# Altium schematic sheet Power.SchDoc
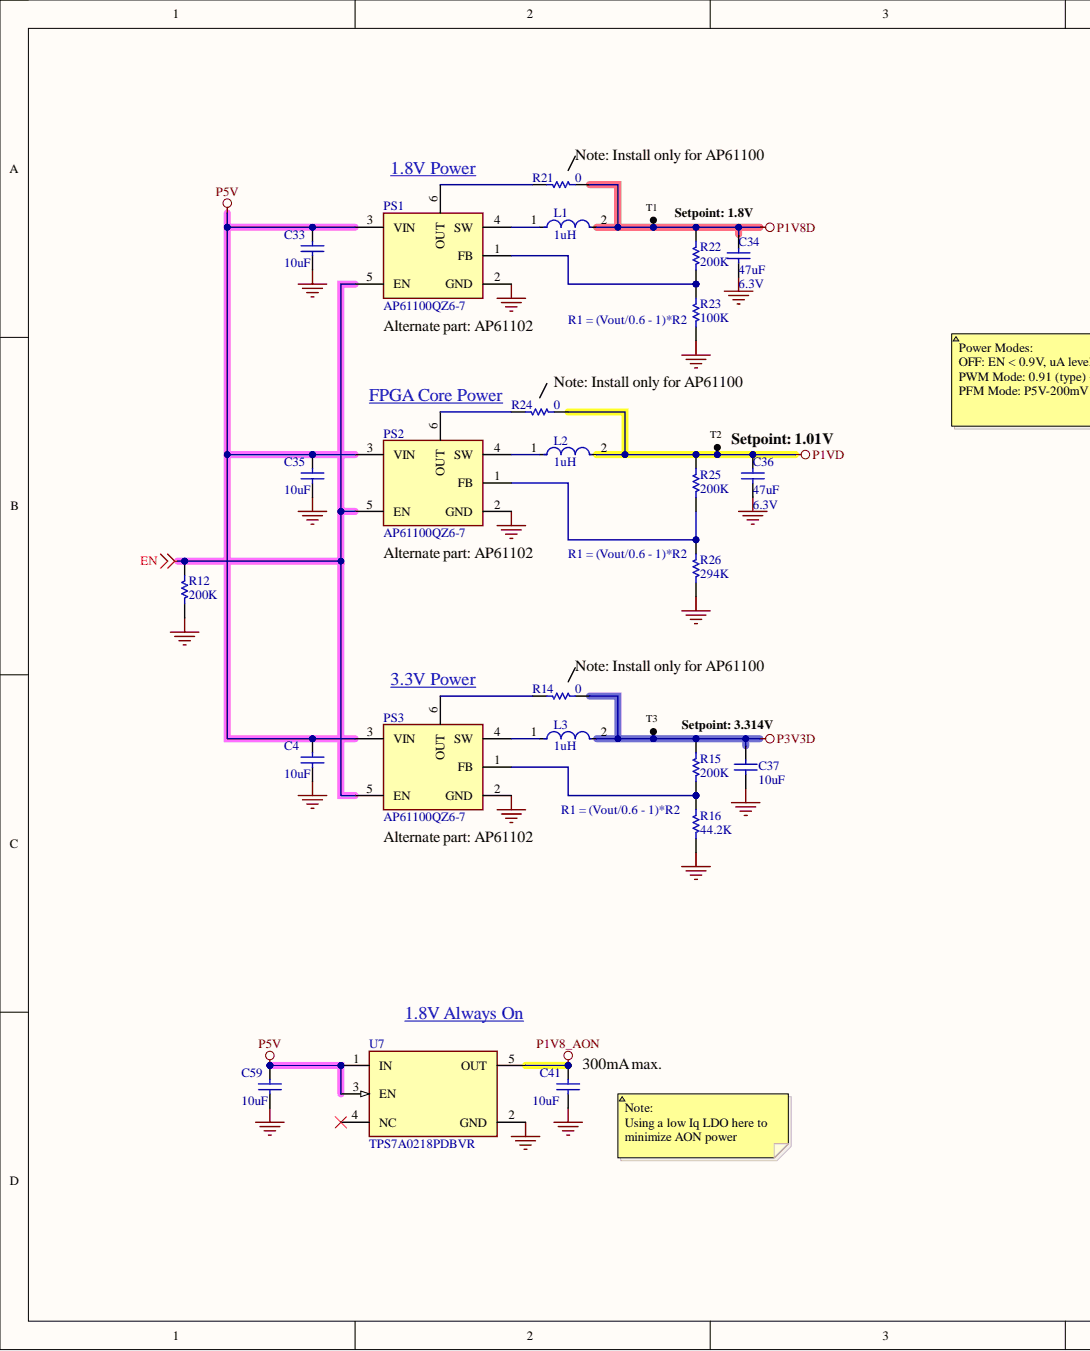
[diagram p0: Power.SchDoc, left]
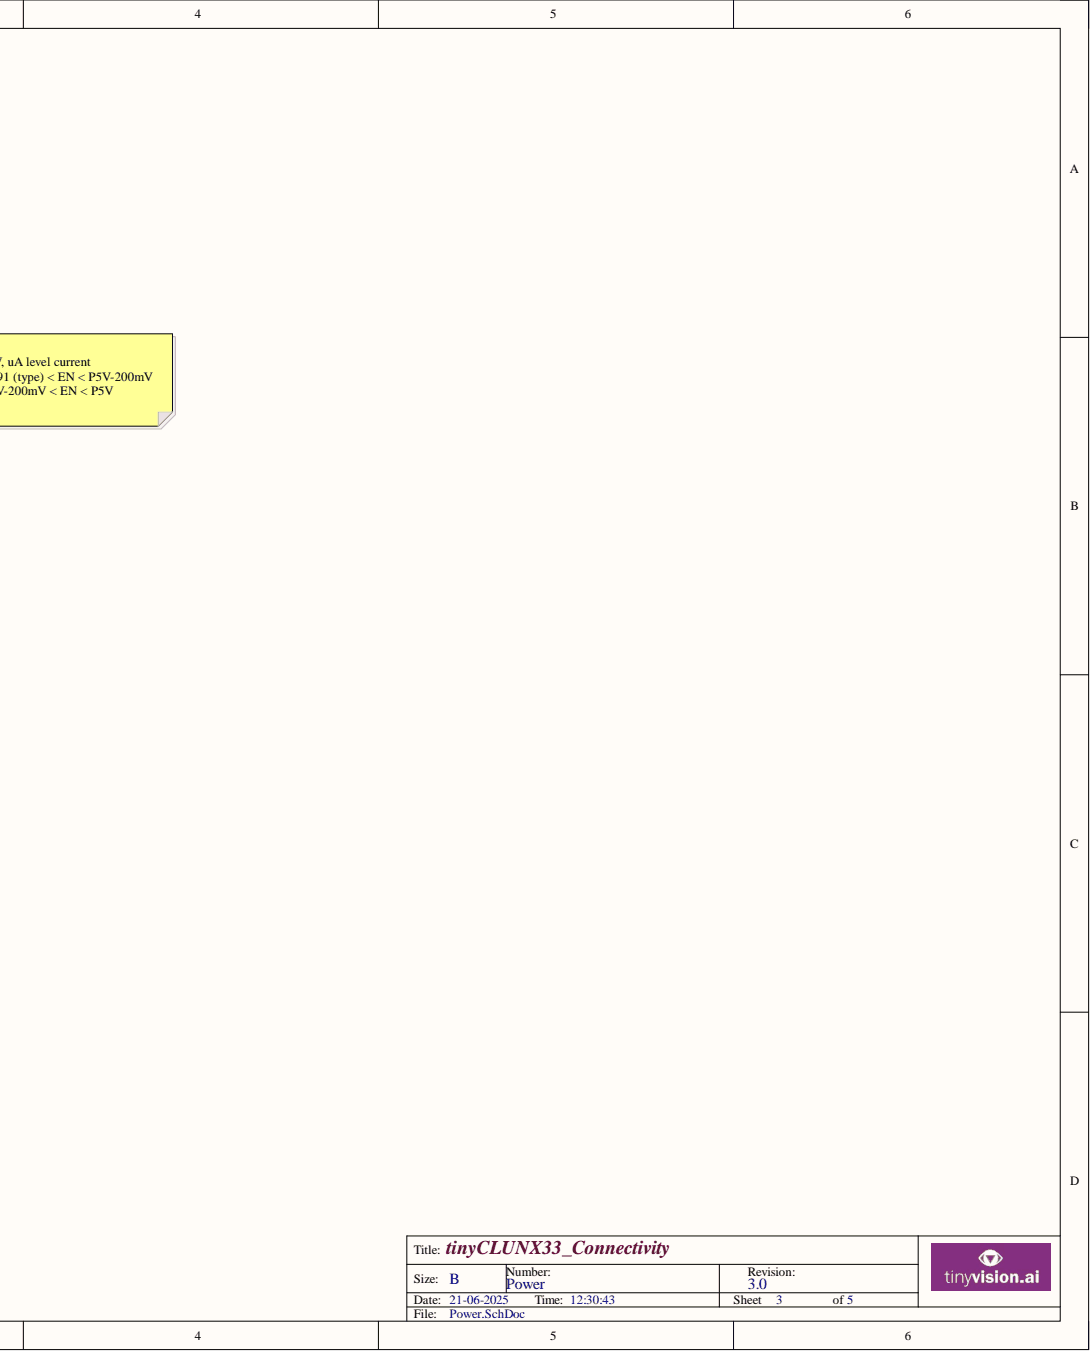
[diagram p1: Power.SchDoc, right]

## Parts
C4  10uF  [CAPC1005X55USR]
C33  10uF  [CAPC1005X55USR]
C34  47uF  Voltage: 6.3V  [CAP_0603]
C35  10uF  [CAPC1005X55USR]
C36  47uF  Voltage: 6.3V  [CAP_0603]
C37  10uF  [CAPC1005X55USR]
C41  10uF  [CAPC1005X55USR]
C59  10uF  [CAPC1005X55USR]
L1  1uH  [INDC2016X100N]
L2  1uH  [INDC2016X100N]
L3  1uH  [INDC2016X100N]
PS1  AP61100QZ6-7  [SOTFL50P160X60-6N]
PS2  AP61100QZ6-7  [SOTFL50P160X60-6N]
PS3  AP61100QZ6-7  [SOTFL50P160X60-6N]
R12  200K  [RESC1005X40USR]
R14  0  [RESC1005X40USR]
R15  200K  [RESC1005X40USR]
R16  44.2K  [RESC1005X40USR]
R21  0  [RESC1005X40USR]
R22  200K  [RESC1005X40USR]
R23  100K  [RESC1005X40USR]
R24  0  [RESC1005X40USR]
R25  200K  [RESC1005X40USR]
R26  294K  [RESC1005X40USR]
T1  3V3  [TP 1mm]  (value not drawn)  (no BOM)
T2  1V0  [TP 1mm]  (value not drawn)  (no BOM)
T3  3V3  [TP 1mm]  (value not drawn)  (no BOM)
U7  TPS7A0218PDBVR  [SOT23-5]

## Nets
EN: PS1.5(EN) PS2.5(EN) PS3.5(EN) R12.2
GND: C4.1 C33.1 C34.1 C35.1 C36.1 C37.1 C41.1 C59.1 PS1.2(GND) PS2.2(GND) PS3.2(GND) R12.1 R16.1 R23.1 R26.1 U7.2(GND)
P1V8_AON: C41.2 U7.5(OUT)
P1V8D: C34.2 L1.2 R21.1 R22.2 T1.1
P1VD: C36.2 L2.2 R24.1 R25.2 T2.1
P3V3D: C37.2 L3.2 R14.1 R15.2 T3.1
P5V: C4.2 C33.2 C35.2 C59.2 PS1.3(VIN) PS2.3(VIN) PS3.3(VIN) U7.1(IN) U7.3(EN)
NetL1_1: L1.1 PS1.4(SW)
NetL2_1: L2.1 PS2.4(SW)
NetL3_1: L3.1 PS3.4(SW)
NetPS1_1: PS1.1(FB) R22.1 R23.2
NetPS1_6: PS1.6(OUT) R21.2
NetPS2_1: PS2.1(FB) R25.1 R26.2
NetPS2_6: PS2.6(OUT) R24.2
NetPS3_1: PS3.1(FB) R15.1 R16.2
NetPS3_6: PS3.6(OUT) R14.2

Not connected: U7 4(NC)

## Library data of the parts
C4 C33 C35 C37 C41 C59 = Cap_10uF_X5R_20%_10V_0402: CAP CER 10UF 10V X5R 0402; Manufacturer: Samsung Electro-Mechanics; Manufacturer Part Number: CL05A106MP5NUNC; Part #: E-CAP-042; Component Kind: Standard; ComponentLink1Description: New link; Height: 0.55mm; Package: 0402; Supplier 1: Digi-Key; Supplier Part Number 1: 1276-1450-1-ND; value: 10uF; Library Name: tinyCLUNX33_Compute.SCHLIB
C34 C36 = Cap_1uF_X5R_10%_6V3_0402: CAP CER 47UF 6.3V X5R 0603; Manufacturer: Murata Electronics; Manufacturer Part Number: GRM188R60J476ME15D; Component Kind: Standard; ComponentLink1Description: New link; Height: 1.0mm; Library Name: Smart Camera.SCHLIB; Package: 0603; Supplier 1: Digi-Key; Supplier Part Number 1: 490-13247-1-ND; value: 47uF
L1-L3 = 725321dda6339637d4d059423bc1c3c: FIXED IND 1UH 2.7A 57 MOHM SMD; Manufacturer: Murata Electronics; Manufacturer Part Number: DFE201610E-1R0M=P2; Component Kind: Standard; Library Name: Smart Camera.SCHLIB; Supplier Part Number 1: 490-18106-1-ND; value: 1uH
PS1-PS3 = AP61102Z6-7: DC-DC CONV LV BUCK SOT563; Manufacturer: Diodes Incorporated; Component Kind: Standard; Height: 0.6mm; Library Name: Smart Camera.SCHLIB; Manufacturer Part Number: AP61100QZ6-7; Supplier 1: Digi-Key; Supplier Part Number 1: 31-AP61100QZ6-7TR-ND
R12 R15 R22 R25 = Res_10K_1%_0402: RES SMD 200K OHM 1% 1/16W 0402; Manufacturer: Stackpole Electronics Inc; Manufacturer Part Number: RMCF0402FT200K; Component Kind: Standard; Height: 0.4mm; Package: 0402; Supplier 1: Digi-Key; Supplier Part Number 1: RMCF0402FT200KTR-ND; value: 200K; Library Name: MCD_Temp_LBE.SchLib
R14 R21 R24 = RES 0 OHM JUMPER 1/20W 0201: RES 0 OHM JUMPER 1/16W 0402; Manufacturer: Yageo; Manufacturer Part Number: RC0402JR-070RL; Component Kind: Standard; Height: 0.4mm; Library Name: Smart Camera v2.SCHLIB; Package: 0402; Supplier 1: Digi-Key; Supplier Part Number 1: 311-0.0JRCT-ND; value: 0
R16 = Res_10K_1%_0402: RES SMD 44.2K OHM 1% 1/16W 0402; Manufacturer: Yageo; Manufacturer Part Number: RC0402FR-0744K2L; Component Kind: Standard; Height: 0.4mm; Package: 0402; Supplier 1: Digi-Key; Supplier Part Number 1: YAG3163TR-ND; value: 44.2K; Library Name: MCD_Temp_LBE.SchLib
R23 = Res_10K_1%_0402: RES 100K OHM 1% 1/16W 0402; Manufacturer: Yageo; Manufacturer Part Number: RC0402FR-07100KL; Component Kind: Standard; Height: 0.4mm; Package: 0402; Supplier 1: Digi-Key; Supplier Part Number 1: 311-100KLRCT-ND; value: 100K; Library Name: MCD_Temp_LBE.SchLib
R26 = Res_10K_1%_0402: RES 294K OHM 1% 1/16W 0402; Manufacturer: YAGEO; Manufacturer Part Number: RC0402FR-07294KL; Component Kind: Standard; Height: 0.4mm; Package: 0402; Supplier 1: Digi-Key; Supplier Part Number 1: YAG3092CT-ND; value: 294K; Library Name: MCD_Temp_LBE.SchLib
T1-T3 = TP_S: test point; Library Name: Smart Camera.SCHLIB
U7 = 04a0194160a0e32602cc4d4157aae62: IC REG LINEAR 1.8V 200MA SOT23-5; Manufacturer: Texas Instruments; Category: Voltage Regulators - Linear; Component Kind: Standard; Library Name: NX Module.SCHLIB; Manufacturer Part Number: TPS7A0218PDBVR; Pricing: 1=1.51000, 10=1.35000, 25=1.28120, 100=1.05230, 250=0.98368, 500=0.86930, 1000=0.68630 (USD); RoHS: Yes; Stock: 21349; Supplier 1: Digi-Key; Supplier Part Number 1: 296-TPS7A0218PDBVRCT-ND
